# Altium schematic sheet pixracer_sd_usb_can_i2c.SchDoc(pixracer_sd_usb_can_i2c)
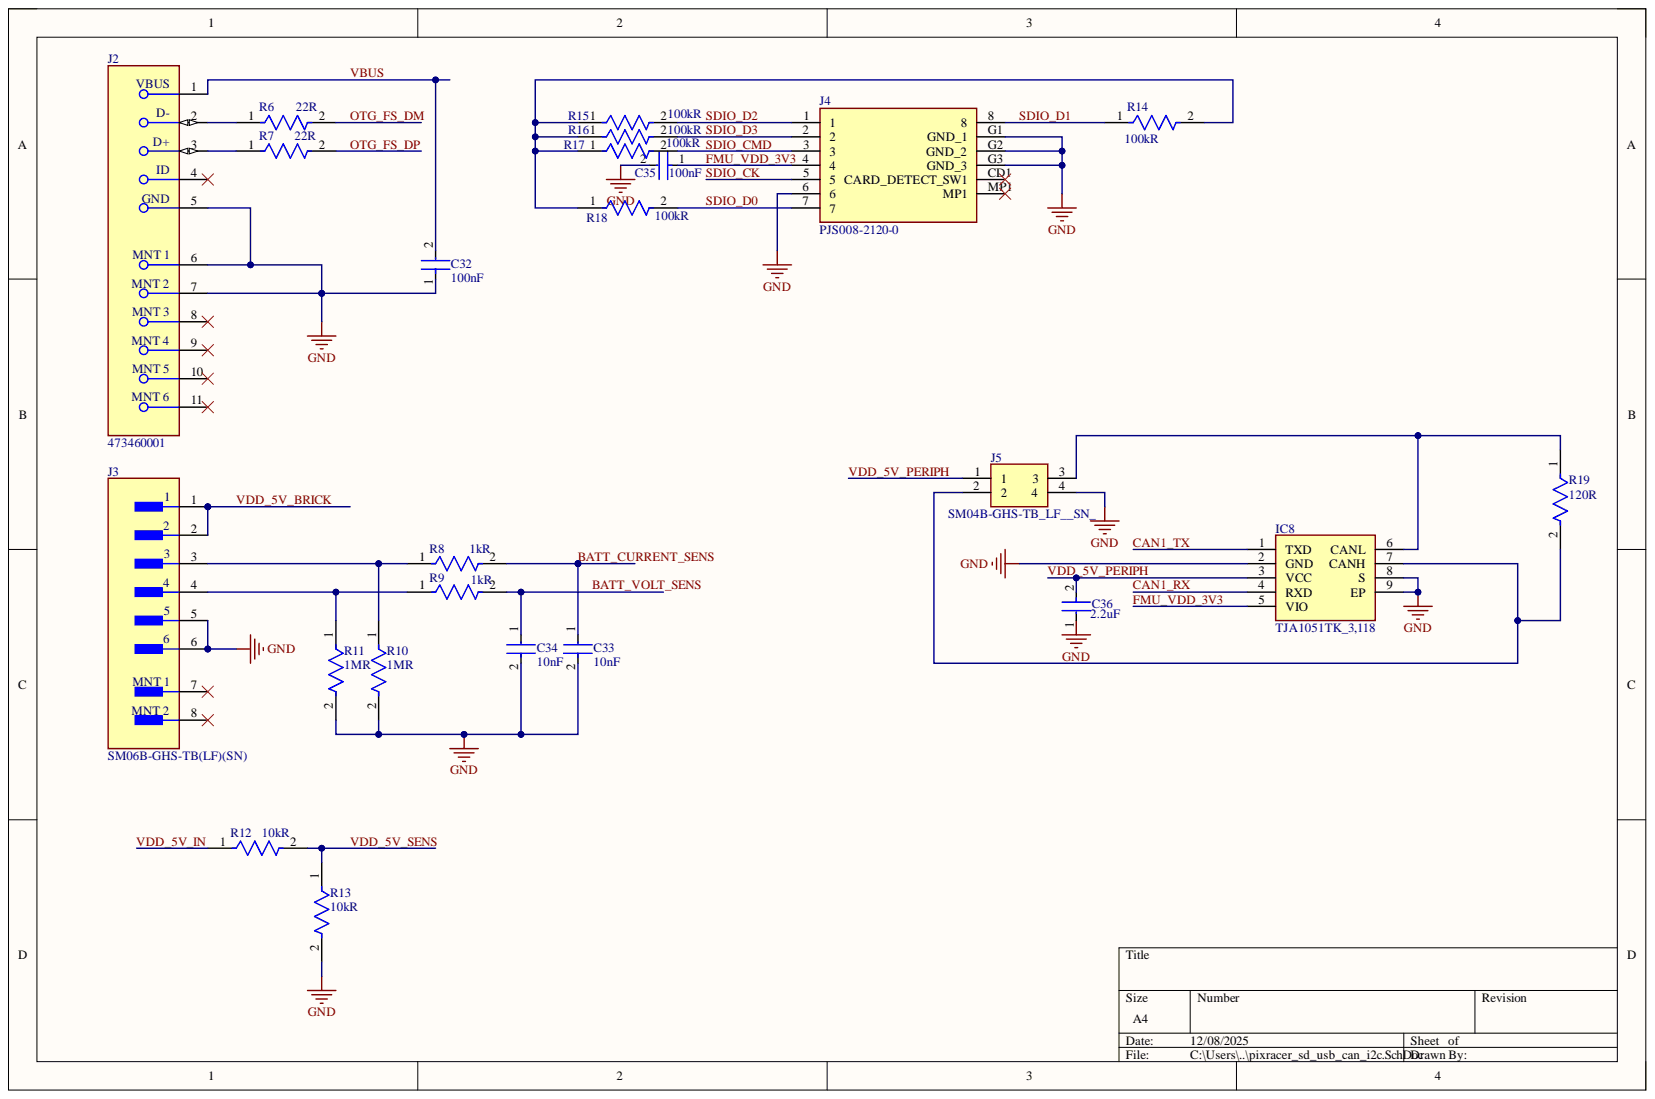
[diagram p0: pixracer_sd_usb_can_i2c.SchDoc]

## Parts
C32  Capacitance: 100nF  [FP-0603-L_1_6_0_15-W_0_81-IPC_B]  (hidden comment: 0603ZC104MAT2A)
C33  Capacitance: 10nF  [FP-C1608-080-0_1-MFG]  (hidden comment: C1608X5R1H103K080AA)
C34  Capacitance: 10nF  [FP-C1608-080-0_1-MFG]  (hidden comment: C1608X5R1H103K080AA)
C35  Capacitance: 100nF  [FP-0603-L_1_6_0_15-W_0_81-IPC_B]  (hidden comment: 0603ZC104MAT2A)
C36  Capacitance: 2.2uF  [FP-C1608-080-0_1-MFG]  (hidden comment: C1608X7R1A225K080AC)
IC8  TJA1051TK_3,118  [SON65P300X300X100-9N-D]
J2  473460001  [FP-473460001-MFG]
J3  SM06B-GHS-TB(LF)(SN)  [FP-SM06B-GHS-TB_LF_SN-MFG]
J4  PJS008-2120-0  [PJS00821200]
J5  SM04B-GHS-TB_LF__SN_  [SM04B-GHS-TB]
R6  Resistance: 22R  [FP-CRCW0603-HPe3-IPC_A]  (hidden comment: CRCW060322R0JNEAHP)
R7  Resistance: 22R  [FP-CRCW0603-HPe3-IPC_A]  (hidden comment: CRCW060322R0JNEAHP)
R8  Resistance: 1kR  [FP-RC1608-IPC_A]  (hidden comment: RC1608J102CS)
R9  Resistance: 1kR  [FP-RC1608-IPC_A]  (hidden comment: RC1608J102CS)
R10  Resistance: 1MR  [FP-CRCW0603-HPe3-IPC_A]  (hidden comment: CRCW06031M00JNEAHP)
R11  Resistance: 1MR  [FP-CRCW0603-HPe3-IPC_A]  (hidden comment: CRCW06031M00JNEAHP)
R12  Resistance: 10kR  [FP-ERJ3G-IPC_C]  (hidden comment: ERJ-3GEYJ103V)
R13  Resistance: 10kR  [FP-ERJ3G-IPC_C]  (hidden comment: ERJ-3GEYJ103V)
R14  Resistance: 100kR  [FP-RMCF0603-IPC_C]  (hidden comment: RMCF0603JT100K)
R15  Resistance: 100kR  [FP-RMCF0603-IPC_C]  (hidden comment: RMCF0603JT100K)
R16  RMCF0603JT100K  [FP-RMCF0603-IPC_C]  (value not drawn)
R17  RMCF0603JT100K  [FP-RMCF0603-IPC_C]  (value not drawn)
R18  Resistance: 100kR  [FP-RMCF0603-IPC_C]  (hidden comment: RMCF0603JT100K)
R19  Resistance: 120R  [FP-MCT0603-MFG]  (hidden comment: MCT06030D1200BP100)

## Nets
GND: C32.1 C33.2 C34.2 C35.2 C36.1 IC8.2(GND) IC8.8(S) IC8.9(EP) J2.5 J2.6 J2.7 J3.5 J3.6 J4.6 J4.G1(GND_1) J4.G2(GND_2) J4.G3(GND_3) J5.4 R10.2 R11.2 R13.2
BATT_CURRENT_SENS: C33.1 R8.2
BATT_VOLT_SENS: C34.1 R9.2
CAN1_RX: IC8.4(RXD)
CAN1_TX: IC8.1(TXD)
FMU_VDD_3V3: C35.1 IC8.5(VIO) J4.4
OTG_FS_DM: R6.2
OTG_FS_DP: R7.2
SDIO_CK: J4.5
SDIO_CMD: J4.3 R17.2
SDIO_D0: J4.7 R18.2
SDIO_D1: J4.8 R14.1
SDIO_D2: J4.1 R15.2
SDIO_D3: J4.2 R16.2
VBUS: C32.2 J2.1
VDD_5V_BRICK: J3.1 J3.2
VDD_5V_IN: R12.1
VDD_5V_PERIPH: C36.2 IC8.3(VCC) J5.1
VDD_5V_SENS: R12.2 R13.1
NetIC8_6: IC8.6(CANL) J5.3 R19.1
NetIC8_7: IC8.7(CANH) J5.2 R19.2
NetJ2_2: J2.2 R6.1
NetJ2_3: J2.3 R7.1
NetJ3_3: J3.3 R8.1 R10.1
NetJ3_4: J3.4 R9.1 R11.1
NetR14_2: R14.2 R15.1 R16.1 R17.1 R18.1

Not connected: J2 4 8 9 10 11; J3 7 8; J4 CD1(CARD_DETECT_SW1) MP1

## Library data of the parts
C32 C35 = CMP-2006-04550-2: General Purpose Ceramic Capacitor, 0603, 100nF, 20%, X7R, 15%, 10V; Case Code (Imperial): 0603; Case Code (Metric): 1608; Case/Package: 0603; Component Kind: Standard; Construction: Flat; Depth: 0.81mm; Dielectric: X7R; Lead Free: Lead Free; Lead Pitch: 0.014inch; Length: 1.6mm; Library Name: Altium Content Vault; Max Operating Temperature: 125°C; Min Operating Temperature: -55°C; Mount: Surface Mount; Number of Pins: 2; Package Quantity: 4000; Packaging: Tape and Reel; Radiation Hardening: No; RoHS Compliant: Yes; Series: -; Termination: SMD/SMT; Thickness: 0.035inch; Tolerance: 20%; Voltage Rating: 10V; Voltage Rating (DC): 10V; Width: 0.032inch
C33 C34 = CMP-08246-005900-1: Multilayer Ceramic Capacitors 10nF ±10% 50V X5R SMD 0603; Case Code (Imperial): 0603; Case Code (Metric): 1608; Case/Package: 0603; Component Kind: Standard; Dielectric Material: Ceramic; Lead Free: Lead Free; Length: 0.063inch; Library Name: Altium Content Vault; Max Operating Temperature: 85°C; Min Operating Temperature: -55°C; Mount: Surface Mount; Package Quantity: 4000; Packaging: Tape and Reel; RoHS Compliant: Yes; Series: C; Thickness: 0.035inch; Tolerance: 10%; Voltage Rating: 50V; Voltage Rating (DC): 50V; Width: 0.031inch
C36 = CMP-08246-006835-1: Multilayer Ceramic Capacitors 2.2μF ±10% 10V X7R SMD 0603; Case Code (Imperial): 0603; Case Code (Metric): 1608; Case/Package: 0603; Component Kind: Standard; Construction: Flat; Depth: 0.8mm; Dielectric: X7R; Dielectric Material: Ceramic; Height: 0.8mm; Lead Free: Lead Free; Length: 1.6mm; Library Name: Altium Content Vault; Max Operating Temperature: 125°C; Min Operating Temperature: -55°C; Mount: Surface Mount; Package Quantity: 4000; Packaging: Tape and Reel; REACH SVHC: No SVHC; Resistor Type: Ceramic; RoHS Compliant: Yes; Series: C; Termination: SMD/SMT; Thickness: 0.037inch; Tolerance: 10%; Voltage Rating: 10V; Voltage Rating (DC): 10V; Weight: 7.1E-05oz; Width: 0.031inch
IC8 = TJA1051TK_3,118: Integrated Circuit; Manufacturer_Name: NXP; Manufacturer_Part_Number: TJA1051TK/3,118; Arrow Part Number: TJA1051TK/3,118; Arrow Price/Stock: https://www.arrow.com/en/products/tja1051tk3118/nxp-semiconductors?region=nac; Component Kind: Standard; Datasheet Link: http://www.nxp.com/docs/en/data-sheet/TJA1051.pdf; Height: 1mm; Mouser Part Number: 771-TJA1051TK/3118; Mouser Price/Stock: https://www.mouser.co.uk/ProductDetail/NXP-Semiconductors/TJA1051TK-3118?qs=fhAOlxDPaYVrDQ2e4g%252BBJA%3D%3D
J2 = CMP-2000-05246-2: CONN RCPT USB2.0 MICRO B SMD R/A; Manufacturer: Molex; Conn Orientation: Right Angle; Current Rating: 1.8A; Is Female: yes; Number Of Contacts: 5; Pitch: 0.65mm; Shielding: true; Voltage Rating: 30V; Automotive: No; Category: Conn; Component Kind: Standard; ComponentLink2Description: Datasheet; ComponentLink2URL: https://www.molex.com/webdocs/datasheets/pdf/en-us/0473460001_IO_CONNECTORS.pdf; Contact Material: Gold,Nickel; Device Class L1: Connectors; Device Class L2: USB Connectors; Device Class L3: unset; Digikey Description: CONN RCPT USB2.0 MICRO B SMD R/A; Footprint Url: https://www.molex.com/pdm_docs/sd/473460001_sd.pdf; Height: 2.945mm; Lead Free: Yes; Library Name: Altium Content Vault; Manufacturer Part Number: 473460001; Octopart: https://octopart.com/47346-0001-molex-7164928; Package: 0; RoHS: TRUE; Temperature: -30°C to +85°C; Temperature Range High: +85°C; Temperature Range Low: -30°C; Usb Standard: USB 2.0
J3 = CMP-17439-000061-1: CONN HEADER SMD R/A 6POS 1.25MM; Connector Type: Outer Shroud Contact; Contact Resistance: 50mR; Current Rating: 1A; Max Voltage Rating (AC): 50V; Number of Contacts: 6; Color: Natural; Component Kind: Standard; Contact Material: Bronze; Contact Plating: Tin; Depth: 4.05mm; Fastening Type: Ramp; Housing Color: Beige; Housing Material: Polyamide; Lead Free: Lead Free; Length: 10.75mm; Library Name: Altium Content Vault; Max Current Rating: 1A; Max Operating Temperature: 85°C; Min Operating Temperature: -25°C; Mount: Surface Mount; Number of Positions: 6; Number of Rows: 1; Orientation: Right Angle; Packaging: Tape and Reel; Pitch: 1.25mm; Termination: Solder; Voltage Rating: 50V
J4 = PJS008-2120-0: Connector; Manufacturer_Name: Yamaichi; Component Kind: Standard; Datasheet Link: https://componentsearchengine.com/Datasheets/1/PJS008-2120-0.pdf; Height: 1.5mm; Manufacturer_Part_Number: PJS008-2120-0; Mouser Part Number: 945-PJS008-2120-0; Mouser Price/Stock: https://www.mouser.co.uk/ProductDetail/Yamaichi-Electronics/PJS008-2120-0?qs=pDnM3xHIg41mTHT1wk7bvQ%3D%3D
J5 = SM04B-GHS-TB_LF__SN_: Connector; Manufacturer_Name: JST (JAPAN SOLDERLESS TERMINALS); Manufacturer_Part_Number: SM04B-GHS-TB(LF)(SN); Component Kind: Standard; Datasheet Link: http://uk.rs-online.com/web/p/products/7521797
R6 R7 = CMP-02407-008302-1: RES Thick Film, 22Ω, 5%, 0.33W, 200ppm/°C, 0603; Power Rating: 250mW; Voltage Rating: 75V; Case Code (Imperial): 0603; Case Code (Metric): 1608; Case/Package: 0603; Component Kind: Standard; Composition: Thick Film; Contact Plating: Tin; Depth: 0.85mm; Height: 0.45mm; Lead Free: Lead Free; Length: 1.6mm; Library Name: Altium Content Vault; Max Operating Temperature: 155°C; Military Standard: Not; Min Operating Temperature: -55°C; Mount: Surface Mount; Package Quantity: 5000; Packaging: Tape and Reel; Radiation Hardening: No; Temperature Coefficient: 200ppm/°C; Tolerance: 5%; Weight: 7.1E-05oz; Width: 0.8mm
R8 R9 = CMP-13261-002301-1: Res Thick Film 0603 1kΩ 5% 0.1W ±100ppm/°C Molded SMD T/R; Max Power Dissipation: 100mW; Voltage Rating (DC): 50V; Case Code (Imperial): 0603; Case Code (Metric): 1608; Case/Package: 0603; Component Kind: Standard; Composition: Thick Film; Contact Plating: Tin; Depth: 0.8mm; Features: Moisture Resistant; Height: 0.022inch; Lead Free: Lead Free; Length: 1.6mm; Library Name: Altium Content Vault; Max Operating Temperature: 155°C; Military Standard: Not; Min Operating Temperature: -55°C; Mount: Surface Mount; Number of Terminations: 2; Packaging: Tape and Reel; Power Rating: 100mW; Radiation Hardening: No; Temperature Coefficient: 100ppm/°C; Tolerance: 5%; Width: 0.031inch
R10 R11 = CMP-02407-009732-1: RES Thick Film, 1MΩ, 5%, 0.33W, 200ppm/°C, 0603; Max Power Dissipation: 250mW; Voltage Rating: 75V; Case Code (Imperial): 0603; Case Code (Metric): 1608; Case/Package: 0603; Component Kind: Standard; Composition: Thick Film; Contact Plating: Tin; Features: Automotive AEC-Q200; Height: 0.022inch; Lead Free: Lead Free; Length: 0.063inch; Library Name: Altium Content Vault; Max Operating Temperature: 155°C; Min Operating Temperature: -55°C; Number of Terminations: 2; Package Quantity: 1; Packaging: Tape and Reel; Power Rating: 250mW; Radiation Hardening: No; Temperature Coefficient: 200ppm/°C; Termination: SMD/SMT; Tolerance: 5%; Weight: 7.1E-05oz; Width: 0.8mm
R12 R13 = CMP-2000-00704-2: RES SMD 10K OHM 5% 1/10W 0603; Max Power Dissipation: 100mW; Temperature Coefficient: 200ppm/°C; Voltage Rating: 75V; Voltage Rating (DC): 50V; Case Code (Imperial): 0603; Case Code (Metric): 1608; Case/Package: 0603; Component Kind: Standard; Construction: Rectangular; Contact Plating: Tin; Depth: 0.8mm; Features: Automotive AEC-Q200; Height: 0.45mm; Lead Free: Lead Free; Length: 1.6mm; Library Name: Altium Content Vault; Max Operating Temperature: 155°C; Military Standard: Not; Min Operating Temperature: -55°C; Mount: Surface Mount; Number of Terminations: 2; Package Quantity: 1; Packaging: Tape and Reel; Power Rating: 100mW; REACH SVHC: No SVHC; Resistance Tolerance: 5%; Resistor Type: Thick Film; RoHS Compliant: Yes; Series: ERJ; Technology: THICK FILM; Termination: SMD/SMT; Tolerance: 5%; Weight: 7.1E-05oz; Width: 0.8mm; Working Voltage: 75V
R14 R15 R18 = CMP-26527-000115-1: 100kΩ ±5% 0.1W 0603 Thick Film Chip Resistor AEC-Q200 compliant; Max Power Dissipation: 100mW; Temperature Coefficient: 100ppm/°C; Case Code (Imperial): 0603; Case Code (Metric): 1608; Case/Package: 0603; Component Kind: Standard; Construction: Rectangular; Contact Plating: Tin; Depth: 0.8mm; Features: Automotive AEC-Q200; Height: 0.022inch; Lead Free: Lead Free; Length: 1.55mm; Library Name: Altium Content Vault; Max Operating Temperature: 155°C; Military Standard: Not; Min Operating Temperature: -55°C; Mount: Surface Mount; Number of Terminations: 2; Packaging: Tape and Reel; Power Rating: 100mW; Radiation Hardening: No; Resistor Type: Thick Film; RoHS Compliant: Yes; Series: RMCF; Tolerance: 5%; Width: 0.031inch
R16 R17 = CMP-26527-000115-1: 100kΩ ±5% 0.1W 0603 Thick Film Chip Resistor AEC-Q200 compliant; Max Power Dissipation: 100mW; Resistance: 100kR; Temperature Coefficient: 100ppm/°C; Case Code (Imperial): 0603; Case Code (Metric): 1608; Case/Package: 0603; Component Kind: Standard; Construction: Rectangular; Contact Plating: Tin; Depth: 0.8mm; Features: Automotive AEC-Q200; Height: 0.022inch; Lead Free: Lead Free; Length: 1.55mm; Library Name: Altium Content Vault; Max Operating Temperature: 155°C; Military Standard: Not; Min Operating Temperature: -55°C; Mount: Surface Mount; Number of Terminations: 2; Packaging: Tape and Reel; Power Rating: 100mW; Radiation Hardening: No; Resistor Type: Thick Film; RoHS Compliant: Yes; Series: RMCF; Tolerance: 5%; Width: 0.031inch
R19 = CMP-02407-011907-1: RES SMD 100 OHM 1% 1/8W 0603; Max Power Dissipation: 100mW; Temperature Coefficient: 25ppm/°C; Tolerance: 0.1%; Case/Package: 0603; Component Kind: Standard; Construction: Rectangular; Contact Plating: Tin; Depth: 0.85mm; Features: Anti-Sulfur; Height: 0.45mm; Lead Free: Lead Free; Length: 1.55mm; Library Name: Altium Content Vault; Max Operating Temperature: 125°C; Military Standard: Not; Min Operating Temperature: -55°C; Mount: Surface Mount; Number of Terminations: 2; Packaging: Tape and Reel; Power Rating: 100mW; Radiation Hardening: No; Resistor Type: Thin Film; RoHS Compliant: Yes; Series: MCT - Precision; Size Code: 0603; Technology: THIN FILM; Width: 850um
